# Altium schematic sheet OpenChill_Main_Board.SchDoc(OpenChill_Main_Board)
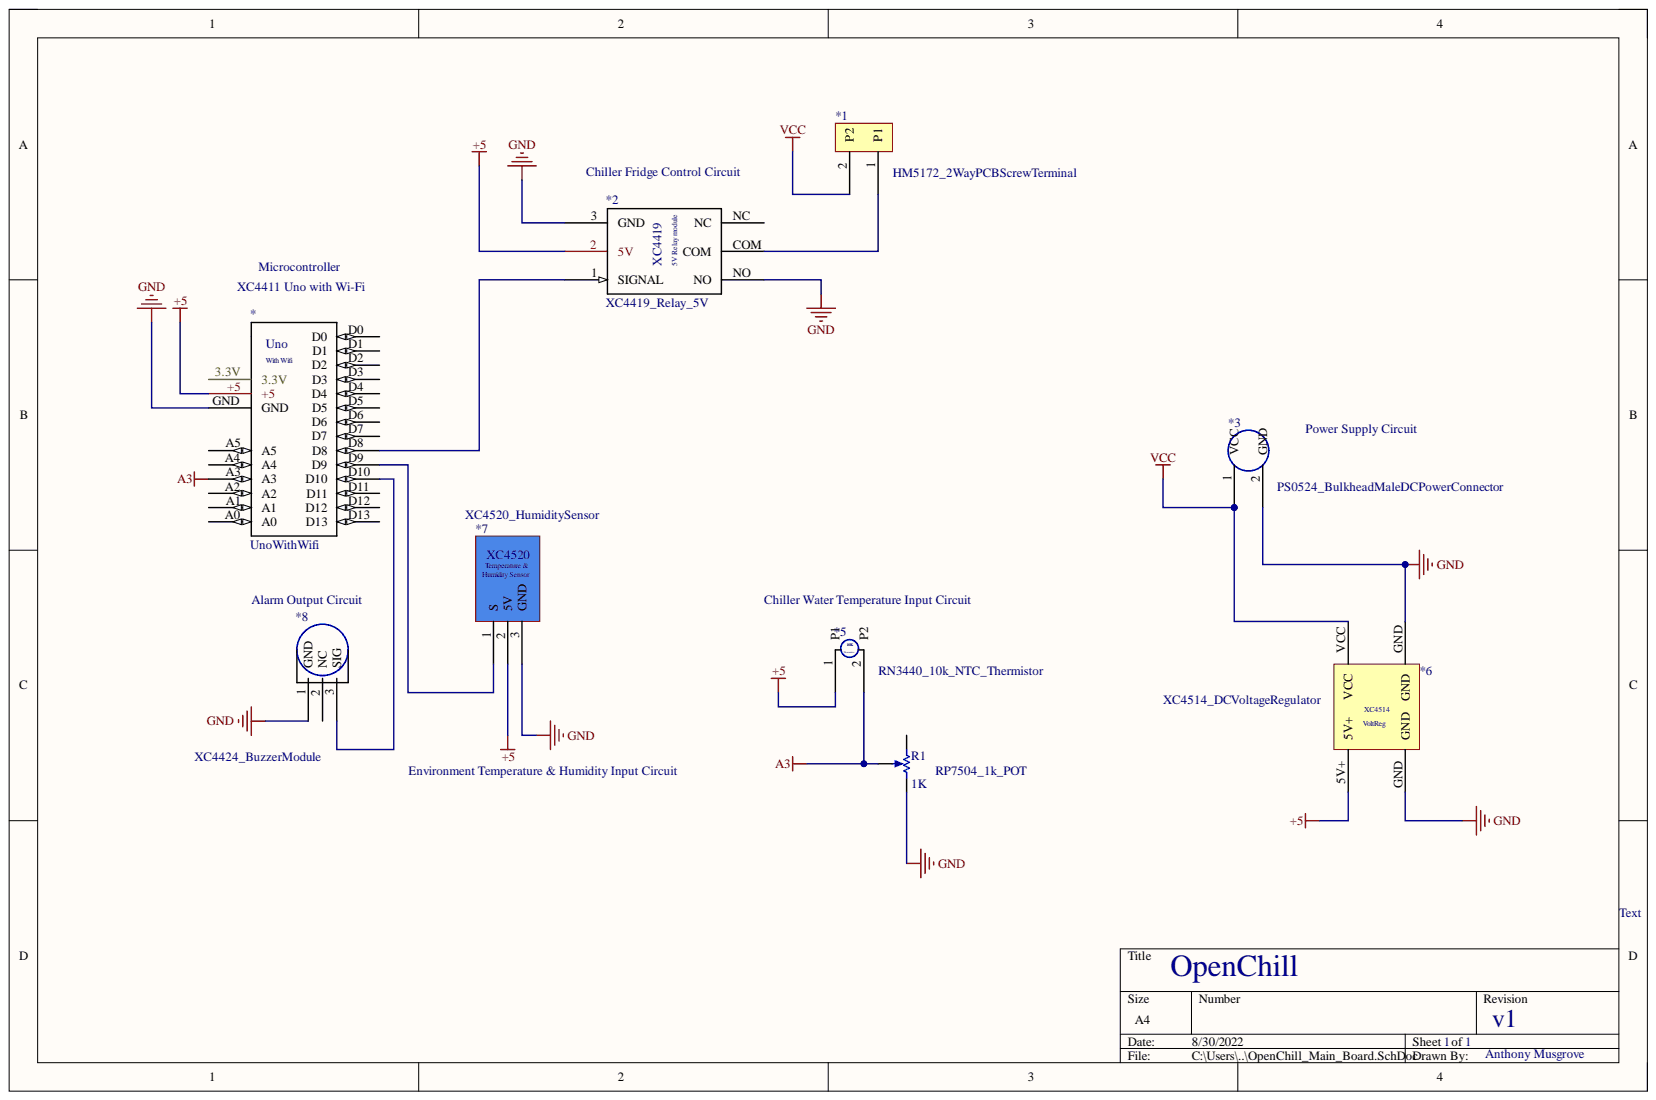
[diagram p0: OpenChill_Main_Board.SchDoc]

## Parts
*  UnoWithWifi  [ARDUINO_INTERFACE_FOOTPRINT]
*1  HM5172_2WayPCBScrewTerminal  [OpenChill_2xThruHole]
*2  XC4419_Relay_5V  [XC4419_5VRelay_Footprint]
*3  PS0524_BulkheadMaleDCPowerConnector  [OpenChill_2xThruHole]
*5  RN3440_10k_NTC_Thermistor  [OpenChill_2xThruHole]
*6  XC4514_DCVoltageRegulator  [XC4514_VoltReg_Footprint]
*7  XC4520_HumiditySensor  [OpenChill_3xThruHole]
*8  XC4424_BuzzerModule  [XC4424_BuzzerModule_Footprint]
R1  RP7504_1k_POT  value 1K  [VR5]

## Nets
+5: *.+5 *2.2(5V) *5.1 *6.5V+ *7.2
A3: *.A3 *5.2 R1.3
GND: *.GND *2.3(GND) *2.NO *3.2 *6.GND *7.3 *8.1 R1.2
VCC: *1.2 *3.1 *6.VCC
Net*1_1: *1.1 *2.COM
Net*2_1: *.D8 *2.1(SIGNAL)
Net*7_1: *.D9 *7.1
Net*8_3: *.D10 *8.3

Not connected: * 3.3V A0 A1 A2 A4 A5 D0 D1 D2 D3 D4 D5 D6 D7 D11 D12 D13; *2 NC; *8 2; R1 1

## Library data of the parts
R1 = RPot: Potentiometer; Set Position: 0.5; Sim Note: Enter the Resistance value and the set point into parameter fields.; Component Kind: Standard; LatestRevisionDate: 17-Jul-2002; LatestRevisionNote: Re-released for DXP Platform.; Library Name: Miscellaneous Devices.IntLib; PackageDescription: Variable Resistor, Thru-Hole; 3 Leads; PackageReference: VR5; Published: 8-Jun-2000; Simulation: POT; Publisher: Altium Limited
* = UnoWithWifi: Component Kind: Standard; Library Name: OpenChill_Components_Library.SchLib
*1 = HM5172_2WayPCBScrewTerminal: Component Kind: Standard; Library Name: OpenChill_Components_Library.SchLib
*2 = XC4419_Relay_5V: Component Kind: Standard; Library Name: OpenChill_Components_Library.SchLib
*3 = PS0524_BulkheadMaleDCPowerConnector: Component Kind: Standard; Library Name: OpenChill_Components_Library.SchLib
*5 = RN3440_10k_NTC_Thermistor: Component Kind: Standard; Library Name: OpenChill_Components_Library.SchLib
*6 = XC4514_DCVoltageRegulator: Component Kind: Standard; Library Name: OpenChill_Components_Library.SchLib
*7 = XC4520_HumiditySensor: Component Kind: Standard; Library Name: OpenChill_Components_Library.SchLib
*8 = XC4424_BuzzerModule: Component Kind: Standard; Library Name: OpenChill_Components_Library.SchLib
